# Altium schematic sheet Sheet2.SchDoc(Sheet2)
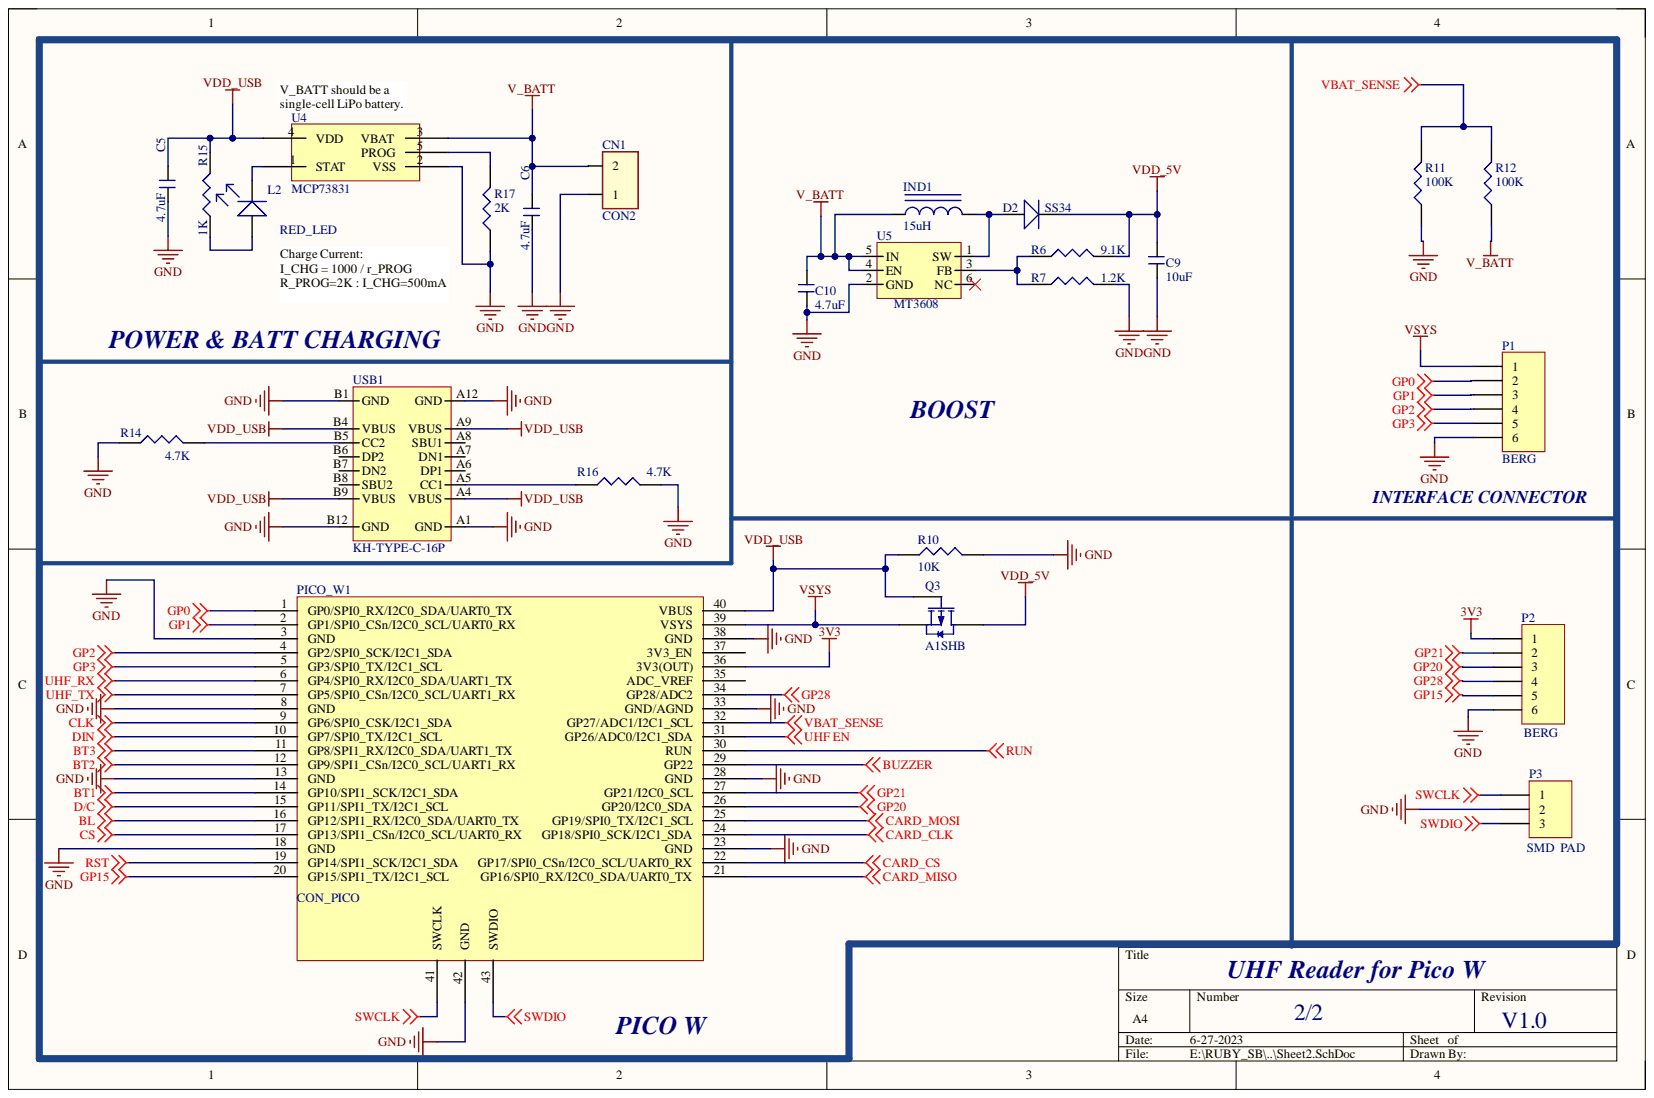
[diagram p0: Sheet2.SchDoc]

## Parts
C5  4.7uF  [C_0603]
C6  4.7uF  [C_0603]
C9  10uF  [C_0603]
C10  4.7uF  [C_0603]
CN1  CON2  [JST2P_P2.0mm]
D2  SS34  [SS34]
IND1  15uH  [IND 15UH]
L2  RED_LED  [LED_0603]
P1  BERG  [6P_2.54MM]
P2  BERG  [6P_2.54MM]
P3  SMD  PAD  [SMD_3P BERG]
PICO_W1  CON_PICO  [PICO_W]
Q3  A1SHB  [BSS84]
R6  9.1K  [R_0603]
R7  1.2K  [R_0603]
R10  10K  [R_0603]
R11  100K  [R_0603]
R12  100K  [R_0603]
R14  4.7K  [R_0603]
R15  1K  [R_0603]
R16  4.7K  [R_0603]
R17  2K  [R_0603]
U4  MCP73831  [SOT23-5]
U5  MT3608  [SOT23-6]
USB1  KH-TYPE-C-16P  [USBC-31-M-12]

## Nets
3V3: P2.1 PICO_W1.36(3V3(OUT))
BL: PICO_W1.16(GP12/SPI1_RX/I2C0_SDA/UART0_TX)
BT1: PICO_W1.14(GP10/SPI1_SCK/I2C1_SDA)
BT2: PICO_W1.12(GP9/SPI1_CSn/I2C0_SCL/UART1_RX)
BT3: PICO_W1.11(GP8/SPI1_RX/I2C0_SDA/UART1_TX)
BUZZER: PICO_W1.29(GP22)
CARD_CLK: PICO_W1.24(GP18/SPI0_SCK/I2C1_SDA)
CARD_CS: PICO_W1.22(GP17/SPI0_CSn/I2C0_SCL/UART0_RX)
CARD_MISO: PICO_W1.21(GP16/SPI0_RX/I2C0_SDA/UART0_TX)
CARD_MOSI: PICO_W1.25(GP19/SPI0_TX/I2C1_SCL)
CLK: PICO_W1.9(GP6/SPI0_CSK/I2C1_SDA)
CS: PICO_W1.17(GP13/SPI1_CSn/I2C0_SCL/UART0_RX)
D/C: PICO_W1.15(GP11/SPI1_TX/I2C1_SCL)
DIN: PICO_W1.10(GP7/SPI0_TX/I2C1_SCL)
GND: C5.2 C6.2 C9.2 C10.2 CN1.1 P1.6 P2.6 P3.2 PICO_W1.3(GND) PICO_W1.8(GND) PICO_W1.13(GND) PICO_W1.18(GND) PICO_W1.23(GND) PICO_W1.28(GND) PICO_W1.33(GND/AGND) PICO_W1.38(GND) PICO_W1.42(GND) R7.2 R10.2 R11.1 R14.1 R16.2 R17.1 U4.2(VSS) U5.2(GND) USB1.A1(GND) USB1.A12(GND) USB1.B1(GND) USB1.B12(GND)
GP0: P1.2 PICO_W1.1(GP0/SPI0_RX/I2C0_SDA/UART0_TX)
GP1: P1.3 PICO_W1.2(GP1/SPI0_CSn/I2C0_SCL/UART0_RX)
GP2: P1.4 PICO_W1.4(GP2/SPI0_SCK/I2C1_SDA)
GP3: P1.5 PICO_W1.5(GP3/SPI0_TX/I2C1_SCL)
GP15: P2.5 PICO_W1.20(GP15/SPI1_TX/I2C1_SCL)
GP20: P2.3 PICO_W1.26(GP20/I2C0_SDA)
GP21: P2.2 PICO_W1.27(GP21/I2C0_SCL)
GP28: P2.4 PICO_W1.34(GP28/ADC2)
RST: PICO_W1.19(GP14/SPI1_SCK/I2C1_SDA)
RUN: PICO_W1.30(RUN)
SWCLK: P3.1 PICO_W1.41(SWCLK)
SWDIO: P3.3 PICO_W1.43(SWDIO)
UHF EN: PICO_W1.31(GP26/ADC0/I2C1_SDA)
UHF_RX: PICO_W1.6(GP4/SPI0_RX/I2C0_SDA/UART1_TX)
UHF_TX: PICO_W1.7(GP5/SPI0_CSn/I2C0_SCL/UART1_RX)
V_BATT: C6.1 C10.1 CN1.2 IND1.1 R12.1 U4.3(VBAT) U5.4(EN) U5.5(IN)
VBAT_SENSE: PICO_W1.32(GP27/ADC1/I2C1_SCL) R11.2 R12.2
VDD_5V: C9.1 D2.K Q3.3 R6.2
VDD_USB: C5.1 PICO_W1.40(VBUS) Q3.1 R10.1 R15.2 U4.4(VDD) USB1.A4(VBUS) USB1.A9(VBUS) USB1.B4(VBUS) USB1.B9(VBUS)
VSYS: P1.1 PICO_W1.39(VSYS) Q3.2
NetD2_A: D2.A IND1.2 U5.1(SW)
NetL2_A: L2.A R15.1
NetL2_K: L2.K U4.1(STAT)
NetR6_1: R6.1 R7.1 U5.3(FB)
NetR14_2: R14.2 USB1.B5(CC2)
NetR16_1: R16.1 USB1.A5(CC1)
NetR17_2: R17.2 U4.5(PROG)

Not connected: PICO_W1 35(ADC_VREF) 37(3V3_EN); U5 6(NC); USB1 A6(DP1) A7(DN1) A8(SBU1) B6(DP2) B7(DN2) B8(SBU2)

## Library data of the parts
C5 C6 C9 C10 = CAP_NONPOLAR: Component Kind: Standard; Library Name: dxp_pasl_lib.IntLib
D2 = DIODE: Component Kind: Standard; Library Name: dxp_pasl_lib.IntLib
IND1 = INDUCTOR: Component Kind: Standard; Library Name: dxp_pasl_lib.IntLib
L2 = LED: Component Kind: Standard; Library Name: dxp_pasl_lib.IntLib
P1 P2 = Header 6: Header, 6-Pin; Component Kind: Standard; LatestRevisionDate: 17-Jul-2002; LatestRevisionNote: Re-released for DXP Platform.; Library Name: Miscellaneous Connectors.IntLib; Signal Integrity: Connector; Publisher: Altium Limited
P3 = Header 3: Header, 3-Pin; Component Kind: Standard; LatestRevisionDate: 17-Jul-2002; LatestRevisionNote: Re-released for DXP Platform.; Library Name: Miscellaneous Connectors.IntLib; Signal Integrity: Connector; Publisher: Altium Limited
PICO_W1 = PICO W: Component Kind: Standard; Library Name: MIX.SchLib
Q3 = BSS84: 50V 130mA 250mW 10Ω 10V,130mA 2V 1mA P Channel SOT-23(SOT-23-3) MOSFETs ROHS #C493579; PackageDocument: 1995/1996; CATEGORY: MOSFET; Component Kind: Standard; LatestRevisionDate: 17-Jul-2002; LatestRevisionNote: Re-released for DXP Platform.; PackageReference: E3; Published: 8-Jun-2000; Library Name: dxp_pasl_lib.IntLib; Publisher: Altium Limited
R6 R7 R10-R12 R14-R17 = RES: Component Kind: Standard; Library Name: dxp_pasl_lib.IntLib
U5 = MT3608: 28V~28V 2V~24V 1.2MHz SOT-23-6 DC-DC Converters ROHS #C84817; Component Kind: Standard; Library Name: dxp_pasl_lib.IntLib
USB1 = USB TYPE-C KH-TYPE-C-16P: 3A 1 Surface Mount 16 Female -40?~+85? Type-C SMD USB Connectors ROHS #  C709357; Component Kind: Standard; ComponentLink2Description: New link; ComponentLink2URL: https://lcsc.com/product-detail/USB-Connectors_Shenzhen-Kinghelm-Elec-KH-TYPE-C-16P_C709357.html; Supplier 1: LCSC; Supplier Part Number 1: C165948; Library Name: dxp_pasl_lib.IntLib
CN1 = CON2: Component Kind: Standard; Library Name: dxp_pasl_lib.IntLib
U4 = MCP73831: Component Kind: Standard; Library Name: dxp_pasl_lib.IntLib
